# Altium schematic sheet ProgrammingInterface.SchDoc(ProgrammingInterface)
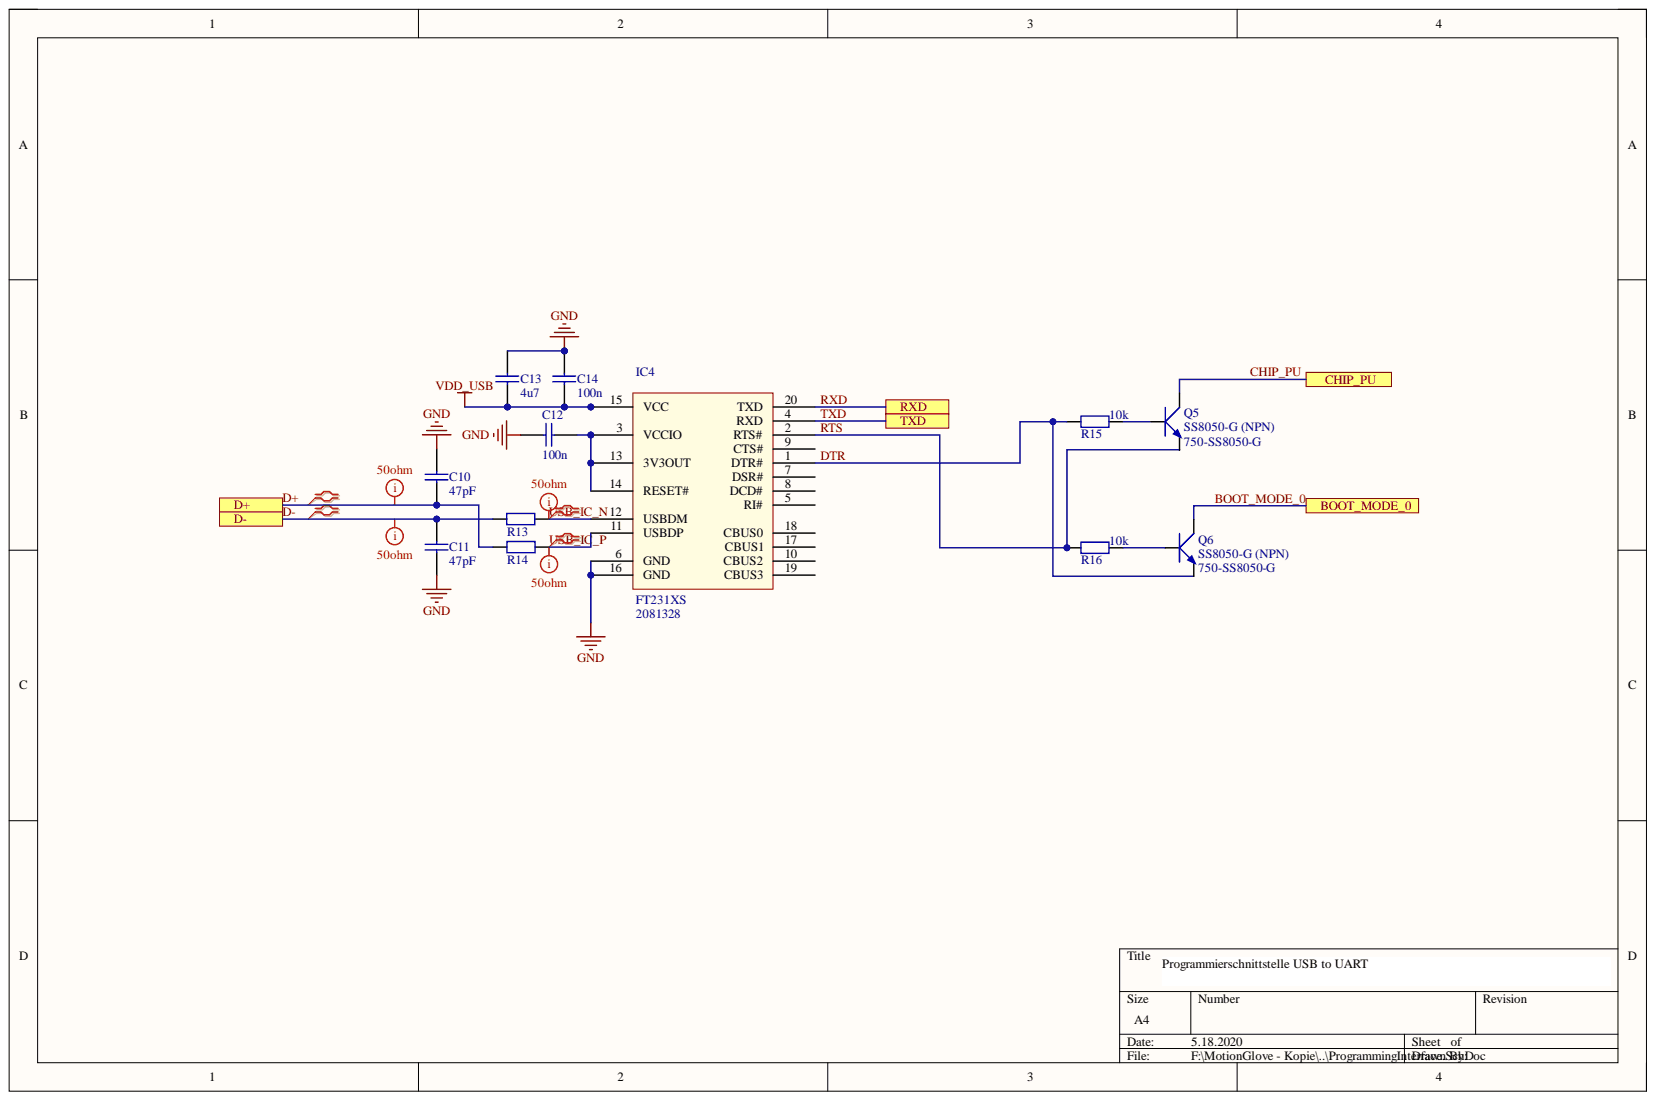
[diagram p0: ProgrammingInterface.SchDoc]

## Parts
C10  47pF  [CAPC1005X55]
C11  47pF  [CAPC1005X55]
C12  100n  [CAPC1005X55]
C13  4u7  [CAPC1005X55]
C14  100n  [CAPC1005X55]
IC4  FT231XS  Farnell: 2081328  [SOP65P600X175-20]
Q5  SS8050-G (NPN)  Supplier Part Number 1: 750-SS8050-G  [SS8050-G (NPN)]
Q6  SS8050-G (NPN)  Supplier Part Number 1: 750-SS8050-G  [SS8050-G (NPN)]
R13  (no value)  [RESC1005X40]
R14  (no value)  [RESC1005X40]
R15  10k  [RESC1005X40]
R16  10k  [RESC1005X40]

## Ports
BOOT_MODE_0, CHIP_PU, D+, D-, RXD, TXD

## Nets
GND: C10.2 C11.1 C12.1 C13.2 C14.2 IC4.6(GND) IC4.16(GND)
VDD_USB: C13.1 C14.1 IC4.15(VCC)
BOOT_MODE_0: Q6.3 ; port BOOT_MODE_0
CHIP_PU: Q5.3 ; port CHIP_PU
D+: C10.1 R14.1 ; port D+
D-: C11.2 R13.1 ; port D-
DTR: IC4.1(DTR#) Q6.2 R15.1
RTS: IC4.2(RTS#) Q5.2 R16.1
RXD: IC4.20(TXD) ; port RXD
TXD: IC4.4(RXD) ; port TXD
USB_IC_N: IC4.12(USBDM) R13.2
USB_IC_P: IC4.11(USBDP) R14.2
NetC12_2: C12.2 IC4.3(VCCIO) IC4.13(3V3OUT) IC4.14(RESET#)
NetQ5_1: Q5.1 R15.2
NetQ6_1: Q6.1 R16.2

Not connected: IC4 5(RI#) 7(DSR#) 8(DCD#) 9(CTS#) 10(CBUS2) 17(CBUS1) 18(CBUS0) 19(CBUS3)

## Library data of the parts
C10 C11 = 1076: Manufacturer: Kemet; Manufacturer ID: C0402C470J5GAC; Characteristic: NP0; Database Table Name: Capacitors; Dielectric: Ceramic; Tolerance: ±5%; Type: Standard Ceramic; UBiKS Number: UBiKS-C00011N; Value: 47p; Voltage: 50V; Component Kind: Standard; ComponentLink1Description: Website; ComponentLink1URL: http://kemet.com/; ComponentLink2Description: Datasheet; ComponentLink2URL: http://www.kemet.com/kemet/web/homepage/kechome.nsf/vapubfiles/F3102_C0G.pdf/$file/F3102_C0G.pdf; Farnell: 1414592; Footprint / Size: C0402; ID: 1076; Quantity: 0; Unit Price: 0; Library Name: SCT_MySQL_5.1.SVNDbLib
C12 C14 = 1066: Manufacturer: Kemet; Manufacturer ID: C0402C104K4RAC; Characteristic: X7R; Database Table Name: Capacitors; Dielectric: Ceramic; Tolerance: ±15%; Type: Standard Ceramic; UBiKS Number: UBiKS-C00001I; Voltage: 16V; Component Kind: Standard; ComponentLink1Description: Website; ComponentLink1URL: http://kemet.com/; ComponentLink2Description: Datasheet; ComponentLink2URL: http://www.kemet.com/kemet/web/homepage/kechome.nsf/vapubfiles/F3102_X7R.pdf/$file/F3102_X7R.pdf; Digikey: 399-3521-1-ND; Distributor 3: Mouser; Distributor Number 3: 80-C0402C104K4RAUTO; Farnell: 1288252; Footprint / Size: C0402; ID: 1066; Quantity: 0; Unit Price: 0; Value: 100n; Library Name: SCT_MySQL_5.1.SVNDbLib
C13 = 1253: Ceramic Chip Capacitor; Manufacturer: Murata; Manufacturer ID: GRM155R60J475ME47D; Characteristic: X5R; Database Table Name: Capacitors; Tolerance: ±20%; Type: Standard Ceramic; UBiKS Number: UBiKS-C00140A; Voltage: 6.3V; Component Kind: Standard; ComponentLink1Description: Website; ComponentLink1URL: http://www.murata.com/; ComponentLink2Description: Datasheet; ComponentLink2URL: http://www.farnell.com/datasheets/1769893.pdf; Dielectric: Ceramic; Farnell: 2426952; Footprint / Size: C0402; ID: 1253; Value: 4u7; Library Name: SCT_MySQL_5.1.SVNDbLib
IC4 = 5331: USB to UART; Manufacturer: FTDI; Database Table Name: ICs; UBiKS Number: UBiKS-U00288M; Component Kind: Standard; ComponentLink1Description: Website; ComponentLink1URL: http://www.ftdichip.com/; ComponentLink2Description: Datasheet; ComponentLink2URL: http://www.ftdichip.com/Support/Documents/DataSheets/ICs/DS_FT231X.pdf; Digikey: 768-1129-1-ND; Distributor Number 3: 895-FT231XS-R; Footprint / Size: SOP-20; ID: 5331; Manufacturer ID: FT231XS; Pins: 20; Supplier 1: Farnell; Supplier Part Number 1: 2081328; Type: USB; Library Name: SCT_MySQL_5.1.SVNDbLib
R13 R14 = 2282: Resistor; Manufacturer: Multicomp; Manufacturer ID: MC 0.0625W 0402 1% 27R; Database Table Name: Resistors; Element Type: Thick Film; Power rating: 0.063W; Temperatur coeff: ±200ppm/°C; Tolerance: ±1%; UBiKS Number: UBiKS-R00154S; Value: 27R; Component Kind: Standard; ComponentLink1Description: Website; ComponentLink2Description: Datasheet; ComponentLink2URL: http://www.farnell.com/datasheets/318400.pdf; Farnell: 1358000; Footprint / Size: R0402; ID: 2282; Quantity: 0; Type: Standard; Unit Price: 0; Library Name: SCT_MySQL_5.1.SVNDbLib
R15 R16 = 2123: Resistor; Manufacturer: Multicomp; Manufacturer ID: MC 0.0625W 0402 1% 10K; Database Table Name: Resistors; Element Type: Thick Film; Power rating: 0.063W; Temperatur coeff: ±100ppm/°C; Tolerance: ±1%; UBiKS Number: UBiKS-R00007H; Component Kind: Standard; ComponentLink1Description: Website; ComponentLink2Description: Datasheet; ComponentLink2URL: http://www.farnell.com/datasheets/318400.pdf; Farnell: 1358069; Footprint / Size: R0402; ID: 2123; Type: Standard; Value: 10k; Library Name: SCT_MySQL_5.1.SVNDbLib
Q5 Q6 = SS8050-G (NPN): Component Kind: Standard; Library Name: Lib.SchLib; Supplier 1: Mouser
